# Altium schematic sheet RS485.SchDoc
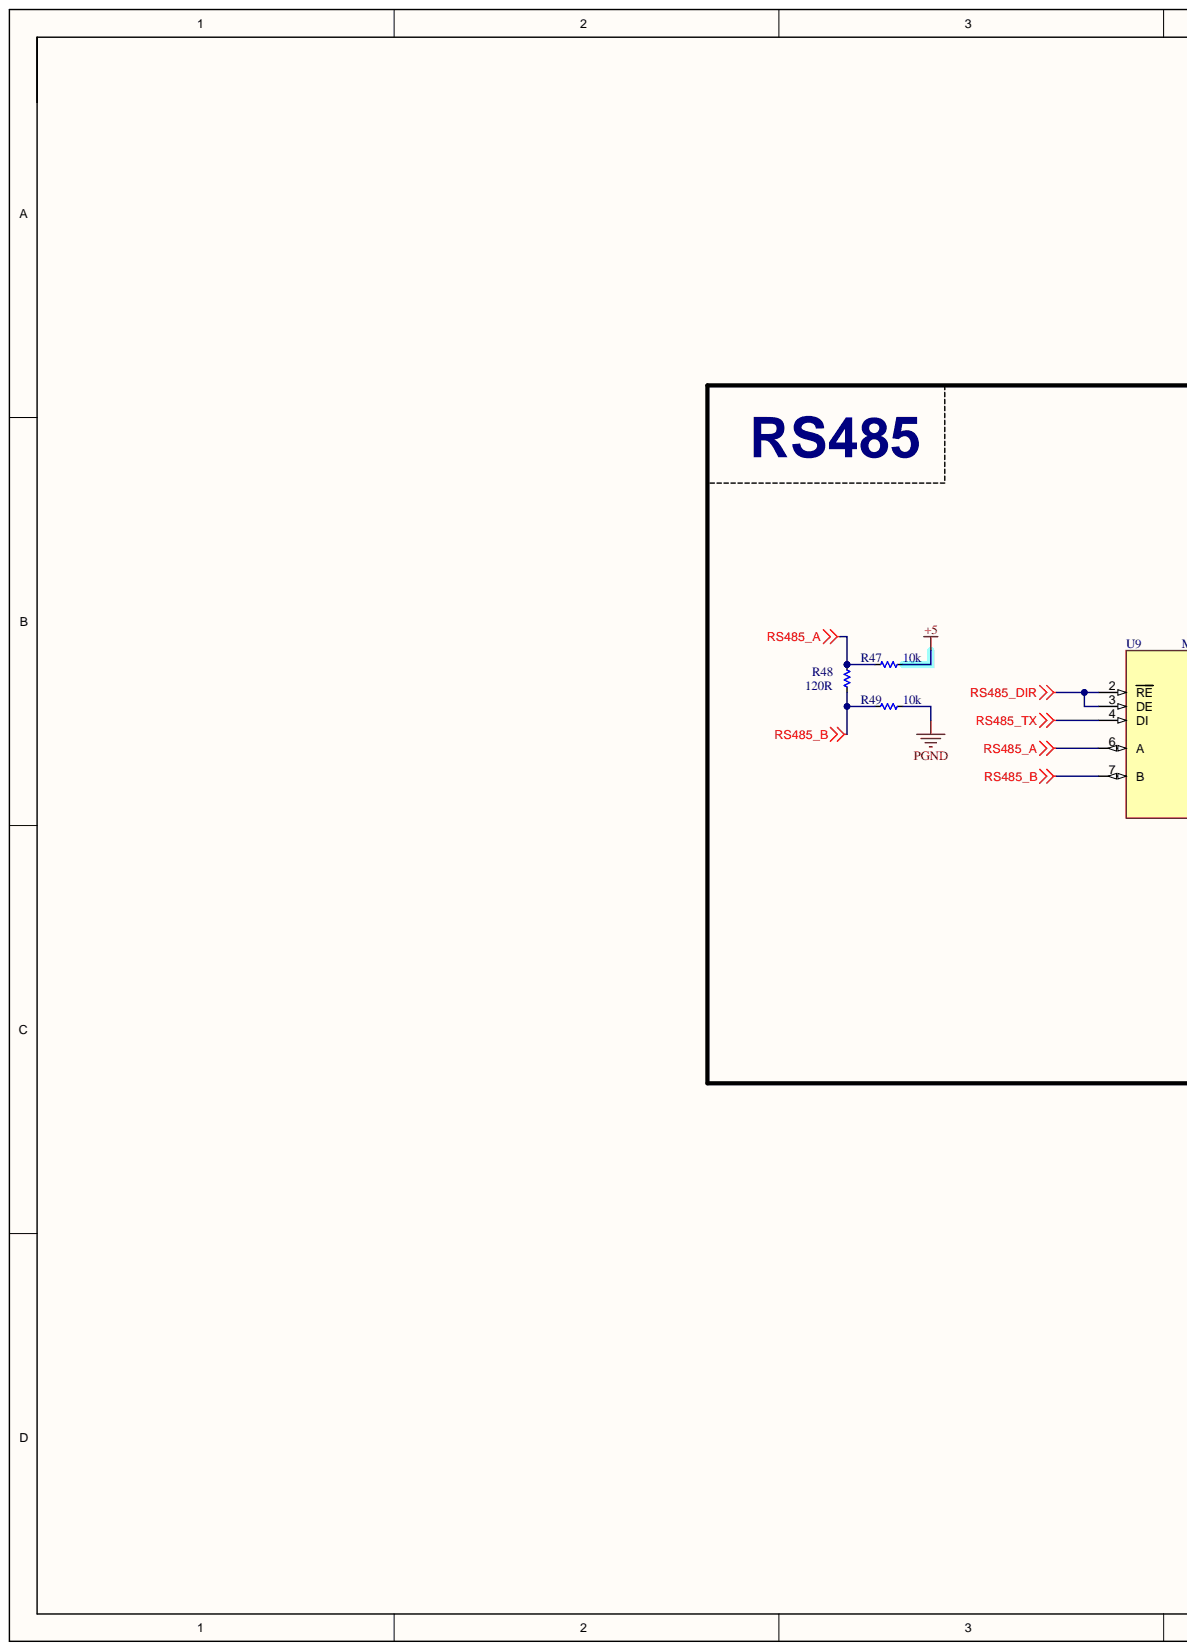
[diagram p0: RS485.SchDoc, left]
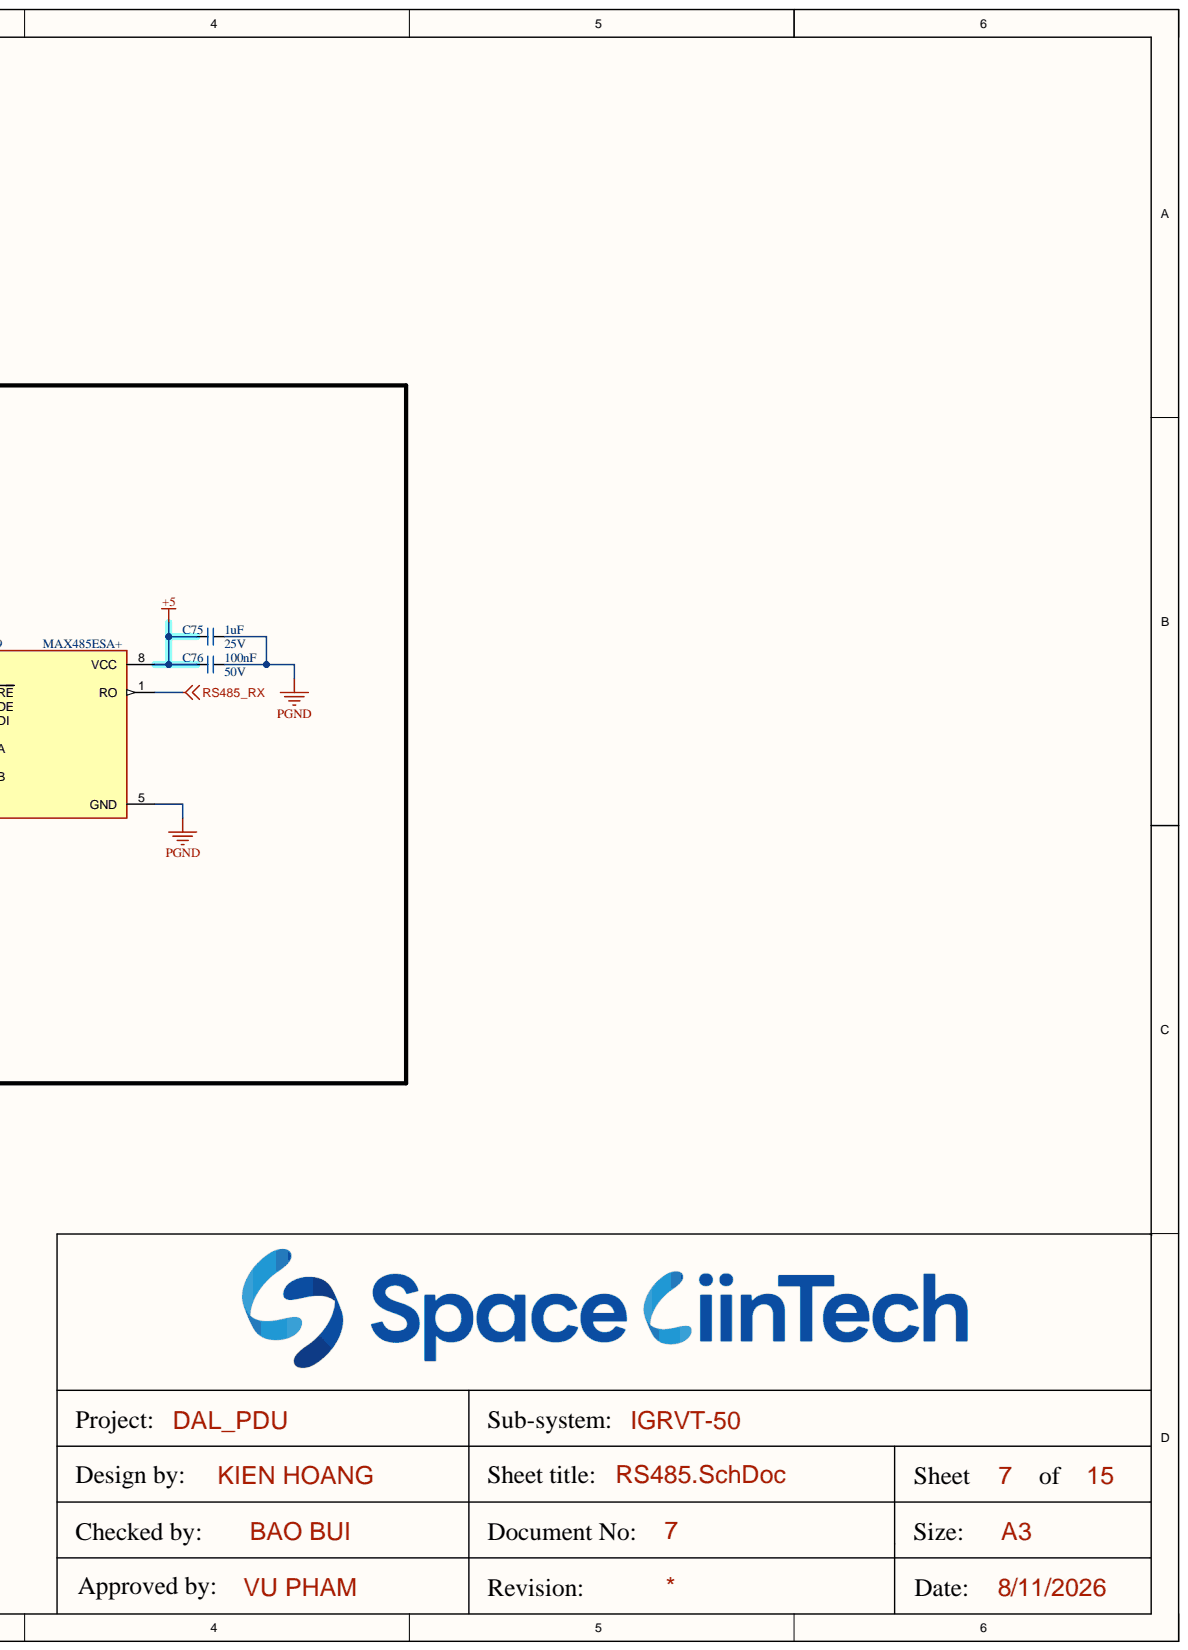
[diagram p1: RS485.SchDoc, right]

## Parts
C75  1uF  Voltage: 25V  [CAP_C_1608X80]
C76  100nF  Voltage: 50V  [CAP_C_1608X80]
R47  10k  [RES_C_1608X60]
R48  120R  [RES_C_2012X65]
R49  10k  [RES_C_1608X60]
U9  MAX485ESA+  [SOIC127]

## Nets
+5: C75.2 C76.2 R47.2 U9.8(VCC)
PGND: C75.1 C76.1 R49.2 U9.5(GND)
RS485_A: R47.1 R48.2 U9.6(A)
RS485_B: R48.1 R49.1 U9.7(B)
RS485_DIR: U9.2(RE) U9.3(DE)
RS485_RX: U9.1(RO)
RS485_TX: U9.4(DI)

## Library data of the parts
C75 C76 = _CAP_C_2: CAP; Component Kind: Standard; Library Name: LABLIB.SchLib
R47-R49 = _RES_B_2_2: RES; Component Kind: Standard; Library Name: LABLIB.SchLib
U9 = MAX485ESA+: Component Kind: Standard; Library Name: KIENASIY123_LIB.IntLib
